# Altium schematic sheet HumidifierV1.1.SchDoc
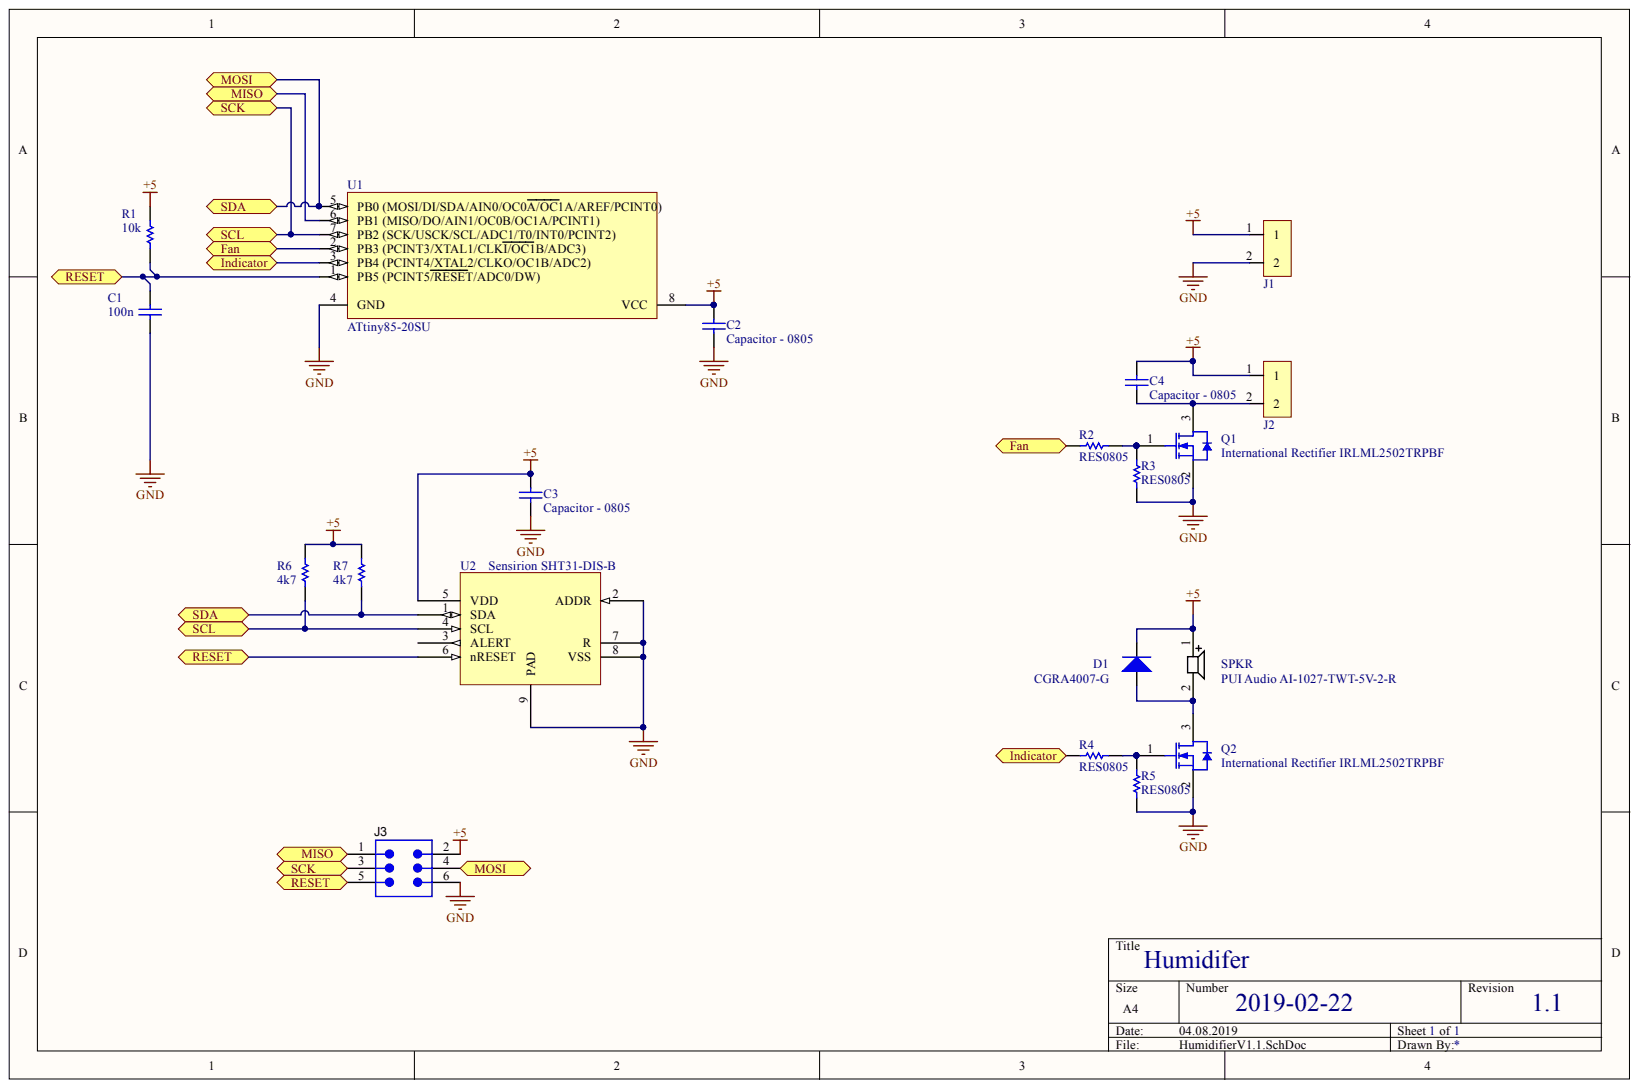
[diagram p0: HumidifierV1.1.SchDoc]

## Parts
C1  Capacitor - 0805  Capacitance: 100n  [PCB-lpeiri214vjl3puxgnh9-1]
C2  Capacitor - 0805  [PCB-lpeiri214vjl3puxgnh9-1]
C3  Capacitor - 0805  [PCB-lpeiri214vjl3puxgnh9-1]
C4  Capacitor - 0805  [PCB-lpeiri214vjl3puxgnh9-1]
D1  CGRA4007-G  [DO-214AC]
J1  Würth Elektronik 61300211121  [PCB-dhbj8ha7d9lvo51vb5c4-1]  (value not drawn)
J2  Würth Elektronik 61300211121  [PCB-dhbj8ha7d9lvo51vb5c4-1]  (value not drawn)
J3  TE Connectivity (Tyco Electronics) 826632-3  [2x3P]  (value not drawn)
Q1  International Rectifier IRLML2502TRPBF  [SOT-23-NB3_M]
Q2  International Rectifier IRLML2502TRPBF  [SOT-23-NB3_M]
R1  Resistance: 10k  [RESC2012X07N]  (hidden comment: RES0805)
R2  RES0805  [RESC2012X07N]
R3  RES0805  [RESC2012X07N]
R4  RES0805  [RESC2012X07N]
R5  RES0805  [RESC2012X07N]
R6  Resistance: 4k7  [RESC2012X07N]  (hidden comment: RES0805)
R7  Resistance: 4k7  [RESC2012X07N]  (hidden comment: RES0805)
SPKR  PUI Audio AI-1027-TWT-5V-2-R  [PCB-6w6tufpnpwyz5hqhip6y-1]
U1  ATtiny85-20SU  [8S2_N]
U2  Sensirion SHT31-DIS-B  Manufacturer Part Number: SHT31-DIS-B  [SHT31]

## Ports
FAN, INDICATOR, MISO, MOSI, RESET, SCK, SCL, SDA

## Nets
+5: C2.2 C3.2 C4.2 D1.K J1.1 J2.1 J3.2 R1.2 R6.2 R7.2 SPKR.1 U1.8(VCC) U2.5(VDD)
GND: C1.1 C2.1 C3.1 J1.2 J3.6 Q1.2 Q2.2 R3.1 R5.1 U1.4(GND) U2.2(ADDR) U2.7(R) U2.8(VSS) U2.9(PAD)
MISO: J3.1 U1.6(PB1_(MISO/DO/AIN1/OC0B/OC1A/PCINT1)) ; port MISO
MOSI: J3.4 R7.1 U1.5(PB0_(MOSI/DI/SDA/AIN0/OC0A/OC1A/AREF/PCINT0)) U2.1(SDA) ; port MOSI, port SDA
RESET: C1.2 J3.5 R1.1 U1.1(PB5_(PCINT5/RESET/ADC0/DW)) U2.6(nRESET) ; port RESET
SCK: J3.3 R6.1 U1.7(PB2_(SCK/USCK/SCL/ADC1/T0/INT0/PCINT2)) U2.4(SCL) ; port SCK, port SCL
NetC4_1: C4.1 J2.2 Q1.3
NetD1_A: D1.A Q2.3 SPKR.2
NetQ1_1: Q1.1 R2.1 R3.2
NetQ2_1: Q2.1 R4.1 R5.2
NetR2_2: R2.2 U1.2(PB3_(PCINT3/XTAL1/CLKI/OC1B/ADC3)) ; port FAN
NetR4_2: R4.2 U1.3(PB4_(PCINT4/XTAL2/CLKO/OC1B/ADC2)) ; port INDICATOR

Not connected: U2 3(ALERT)

## Library data of the parts
C1-C4 = CMP-ojos3o04nzwvfvuxwtw7-2: A generic 0805 capacitor; Component Kind: Standard; CustomComponentID: ojos3o04nzwvfvuxwtw7
  C1: Library Name: CommunityVault
  C2-C4: Library Name: FavoritesLibrary
D1 = CMP-815302-9: DIODE GEN PURP 1KV 1A DO214AC; Component Kind: Standard; Library Name: CommunityVault
J1 J2 = CMP-748fbcb73d6bab48-9: THT Vertical Pin Header WR-PHD, Pitch 2.54 mm, Single Row, 2 pins; Current Rating: 3.00 A; Dielectric Withstanding Voltage: 500 V; Gender: Male; Number of Contacts: 2; Pin Pitch: 2.54 mm; Component Kind: Standard; Contact Material: Copper; Contact Plating: Gold; Library Name: CommunityVault; Manufacturer Part Number: 61300211121; Mounting Style: Through Hole; Number of Pins: 2; OctopartID: 748fbcb73d6bab48; Octopart URL: https://octopart.com/61300211121-w%C3%BCrth+elektronik-20081794; Operating Temperature: -40.0 °C (min); Orientation: Vertical; Packaging: Bag; REACH SVHC Compliance: No SVHC; RoHS: Compliant
J3 = CMP-18f2d1e5a96ec735-2: Conn Unshrouded Header HDR 6 POS 2.54mm Solder ST Thru-Hole Automotive Carton; Current Rating: 3.00 A; ELV Compliant: Compliant; Gender: Male; Lead-Free Status: Lead Free; Number of Contacts: 6; Component Kind: Standard; Contact Material: Phosphor Bronze; Contact Plating: Gold, Tin; Flammability Rating: UL 94 V-0; Housing Color: Green; Housing Material: Thermoplastic; Library Name: CommunityVault; Lifecycle Status: Active; Manufacturer Part Number: 826632-3; Mounting Style: PCB; OctopartID: 18f2d1e5a96ec735; Octopart Url: http://octopart.com/826632-3-te+connectivity+%2F+amp-1938682; Orientation: Vertical; Pin Pitch: 2.54 mm; REACH SVHC Compliance: No SVHC; RoHS: Compliant; Seller Landing  Url: https://octopart-clicks.com/click/track?country=US&ak=8157f166&sig=0f290fb&sid=5489&ppid=1938682&vpid=271695964&ct=offers
Q1 Q2 = CMP-2602693-2: MOSFET MOSFT 20V 4.2A 45mOhm 8nC Log Lvl; Drain to Source Voltage (Vds): 20.0 V; Lead-Free Status: Lead Free; Polarity: N-Channel; Power Dissipation: 1.25 W; Rise Time: 1e-08 s; Case/Package: SOT-23; Component Kind: Standard; Continuous Drain Current (Ids): 4.2 A; Current Rating: 4.2 A; Library Name: CommunityVault; Lifecycle Status: Active; Manufacturer Part Number: IRLML2502TRPBF; Mounting Style: Surface Mount; Number of Pins: 3; OctopartID: 7b6ab2656f28a4ad; Octopart Url: https://octopart.com/irlml2502trpbf-international+rectifier-340260; Packaging: Cut Tape (CT); Part Family: IRLML2502; RoHS: Compliant; Voltage Rating (DC): 20.0 V
R1 R6 R7 = CMP-nzb255ewwa0t8x8sm0p7-1: Generic 0805 Resistor; Body Height: 0.6mm; Body Length: 2mm; Body Width: 1.25mm; Package (Imperial): 0805; Package (Metric): 2012; Component Kind: Standard; CustomComponentID: nzb255ewwa0t8x8sm0p7; Library Name: CommunityVault
R2-R5 = CMP-nzb255ewwa0t8x8sm0p7-1: Generic 0805 Resistor; Body Height: 0.6mm; Body Length: 2mm; Body Width: 1.25mm; Package (Imperial): 0805; Package (Metric): 2012; Resistance: 10K?; Component Kind: Standard; CustomComponentID: nzb255ewwa0t8x8sm0p7; Library Name: FavoritesLibrary
SPKR = CMP-7393b99b6ef3ac54-2: Audio Indicator Indicator Piezo 30mA Pin 3Vdc 2430Hz to 3030Hz Through Hole; Component Kind: Standard; Library Name: CommunityVault
U1 = CMP-0093-00173-3: 8-bit AVR Microcontroller, 20MHz, 2.7-5.5V, 8K Flash, 512 Bytes EEPROM, 512 Bytes SRAM, Industrial Grade (-40°C to 85°C), 8-Lead SOIC, Pb-Free; Access Time: 2e-05 s; Clock Speed: 20000000.0 Hz; FLASH Memory Size: 8192 B; Lead-Free Status: Lead Free; Number of I/O Pins: 6; RAM Memory Size: 512 B; Supply Voltage (DC): 5.0 V; Case/Package: SOIC; Component Kind: Standard; Core Architecture: AVR; Library Name: CommunityVault; Manufacturer Part Number: ATTINY85-20SU; Mounting Style: Surface Mount; Number of Pins: 8; OctopartID: 4ed985889323911e; Octopart Url: https://octopart.com/attiny85-20su-atmel-39581852; Packaging: Tube; REACH SVHC Compliance: No SVHC; RoHS: Compliant
U2 = CMP-932f5952507a4ce8-3: Humidity Sensor, 2 %, 3.3 V, 0% to 100% Relative Humidity, TDFN, 125 C, 8; Lead-Free Status: Lead Free; Supply Voltage (DC): 3.30 V; Component Kind: Standard; Library Name: CommunityVault; Number of Pins: 8; OctopartID: 932f5952507a4ce8; Octopart URL: http://octopart.com/sht31-dis-b-sensirion-58189363; Packaging: Cut Tape (CT); RoHS: Compliant
